# Altium schematic sheet LClock.SchDoc(LClock)
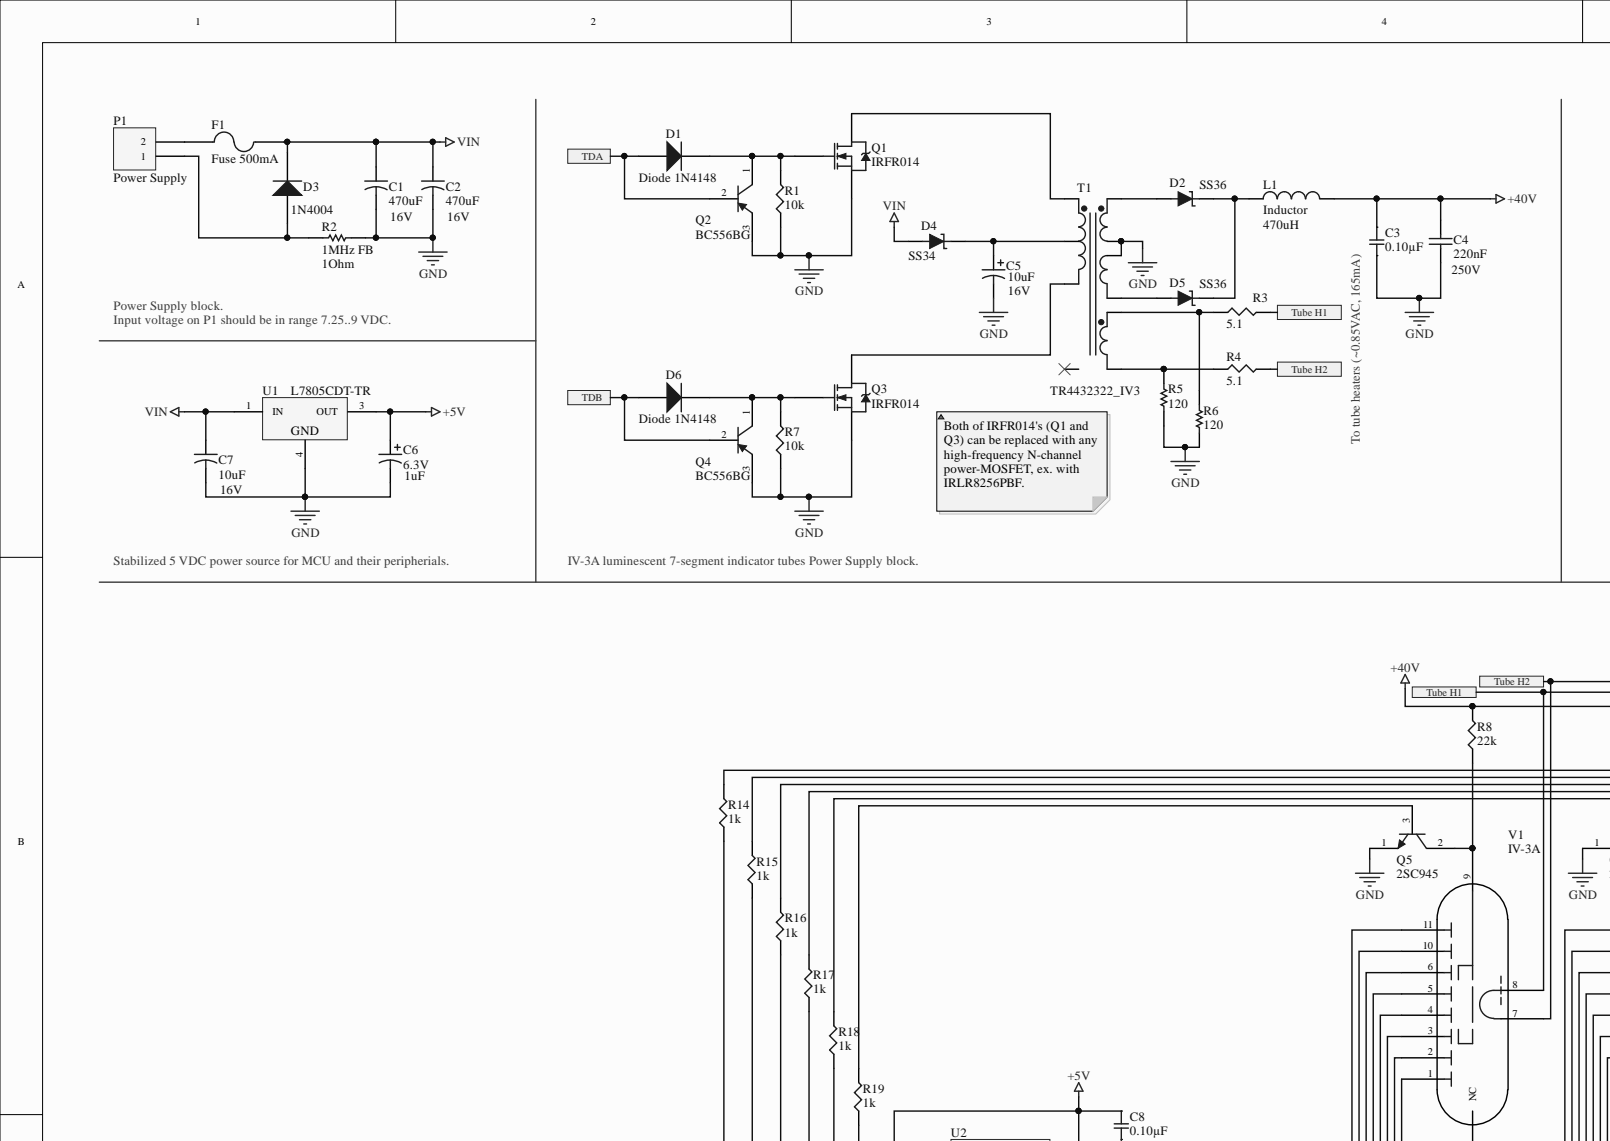
[diagram p0: LClock.SchDoc, top left]
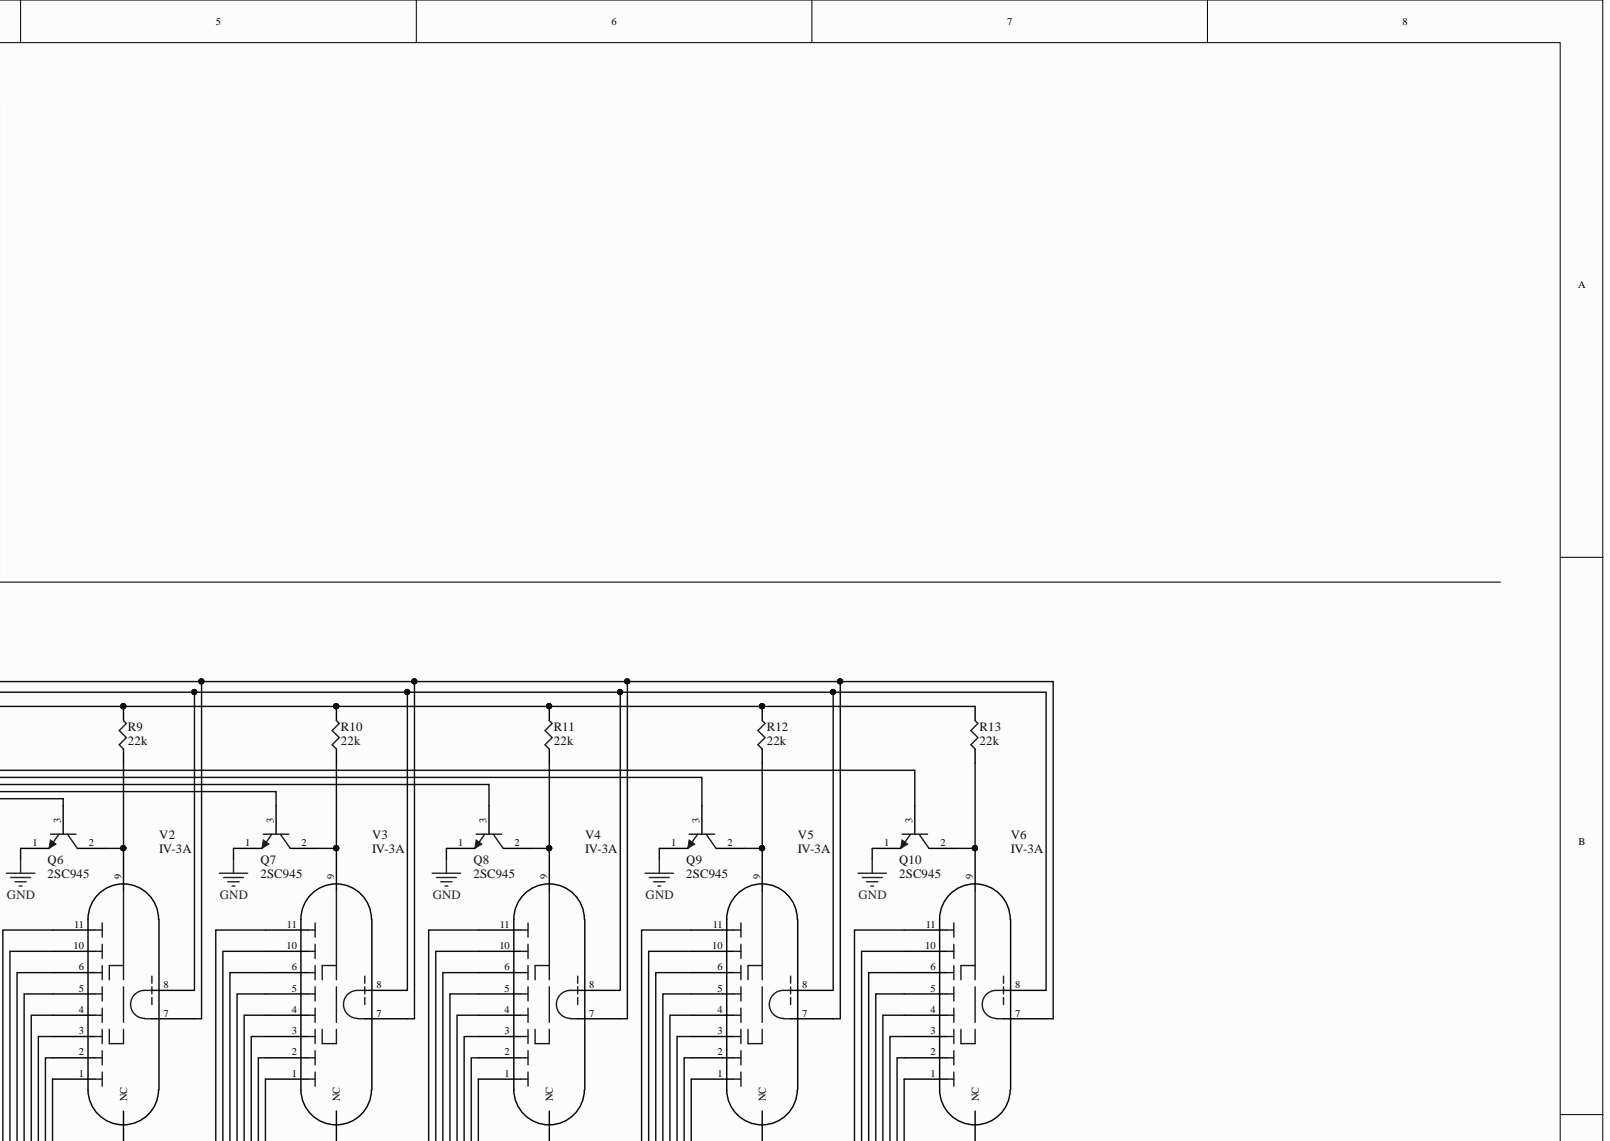
[diagram p1: LClock.SchDoc, top right]
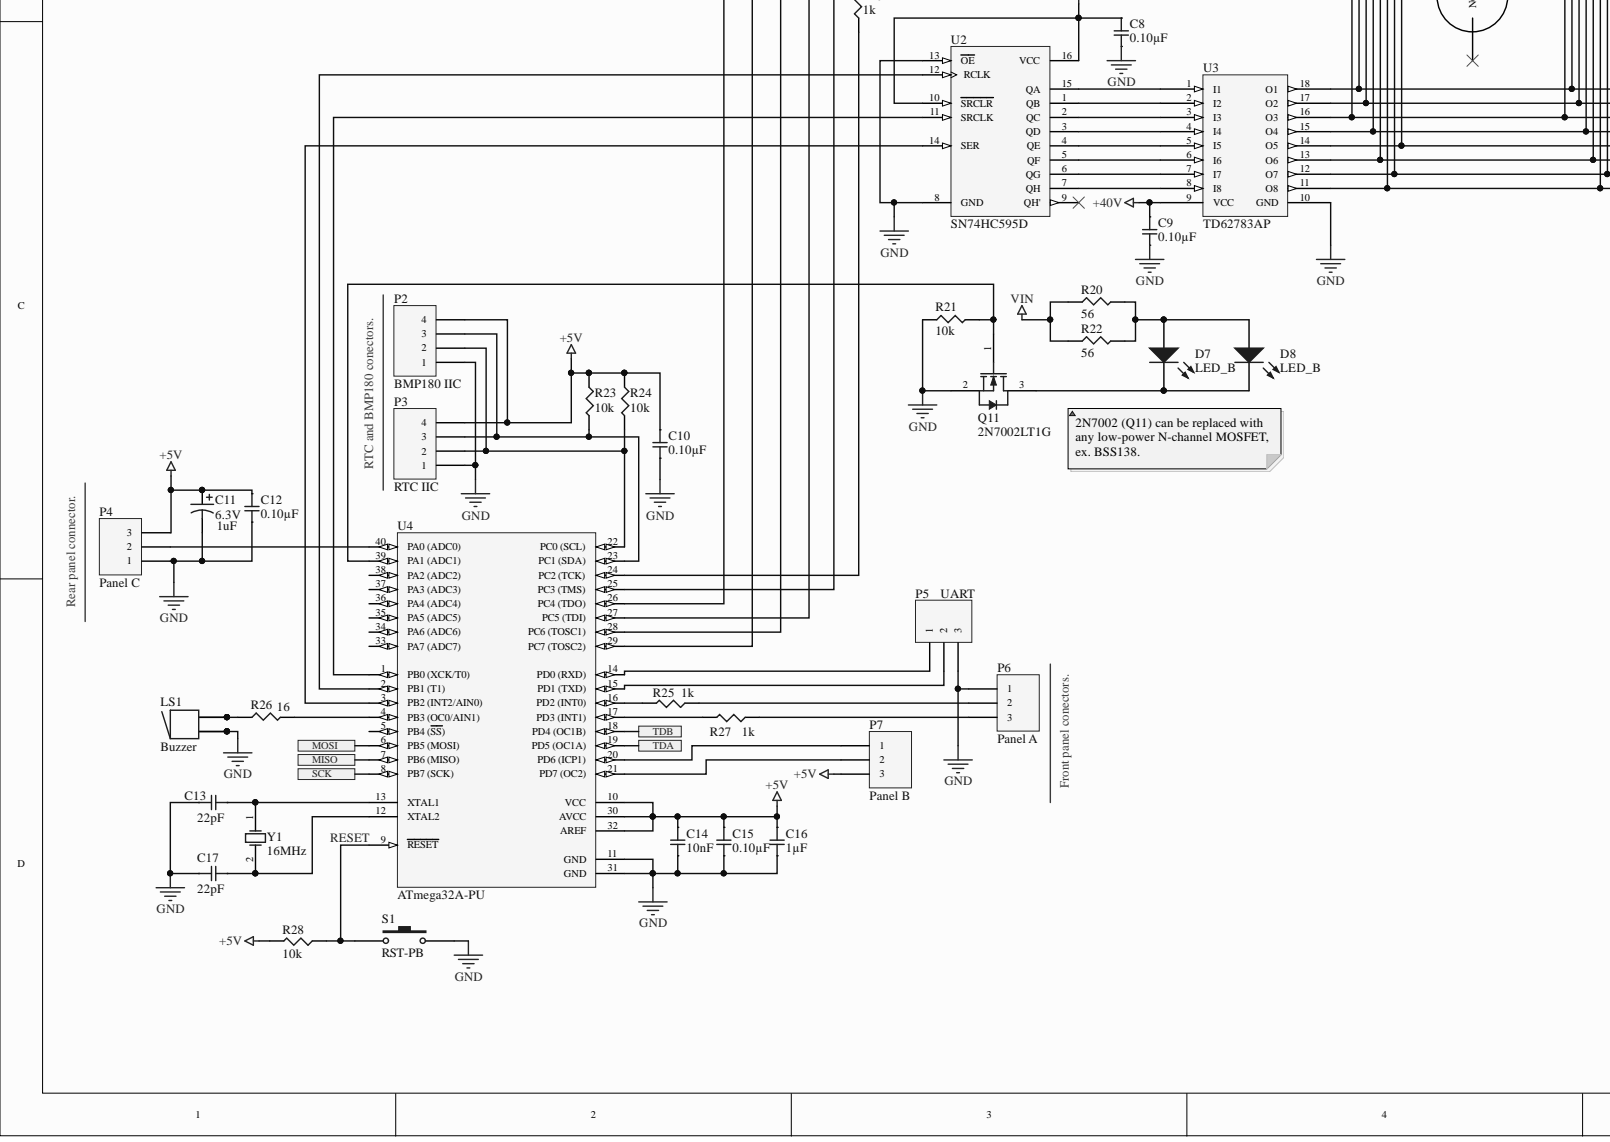
[diagram p2: LClock.SchDoc, bottom left]
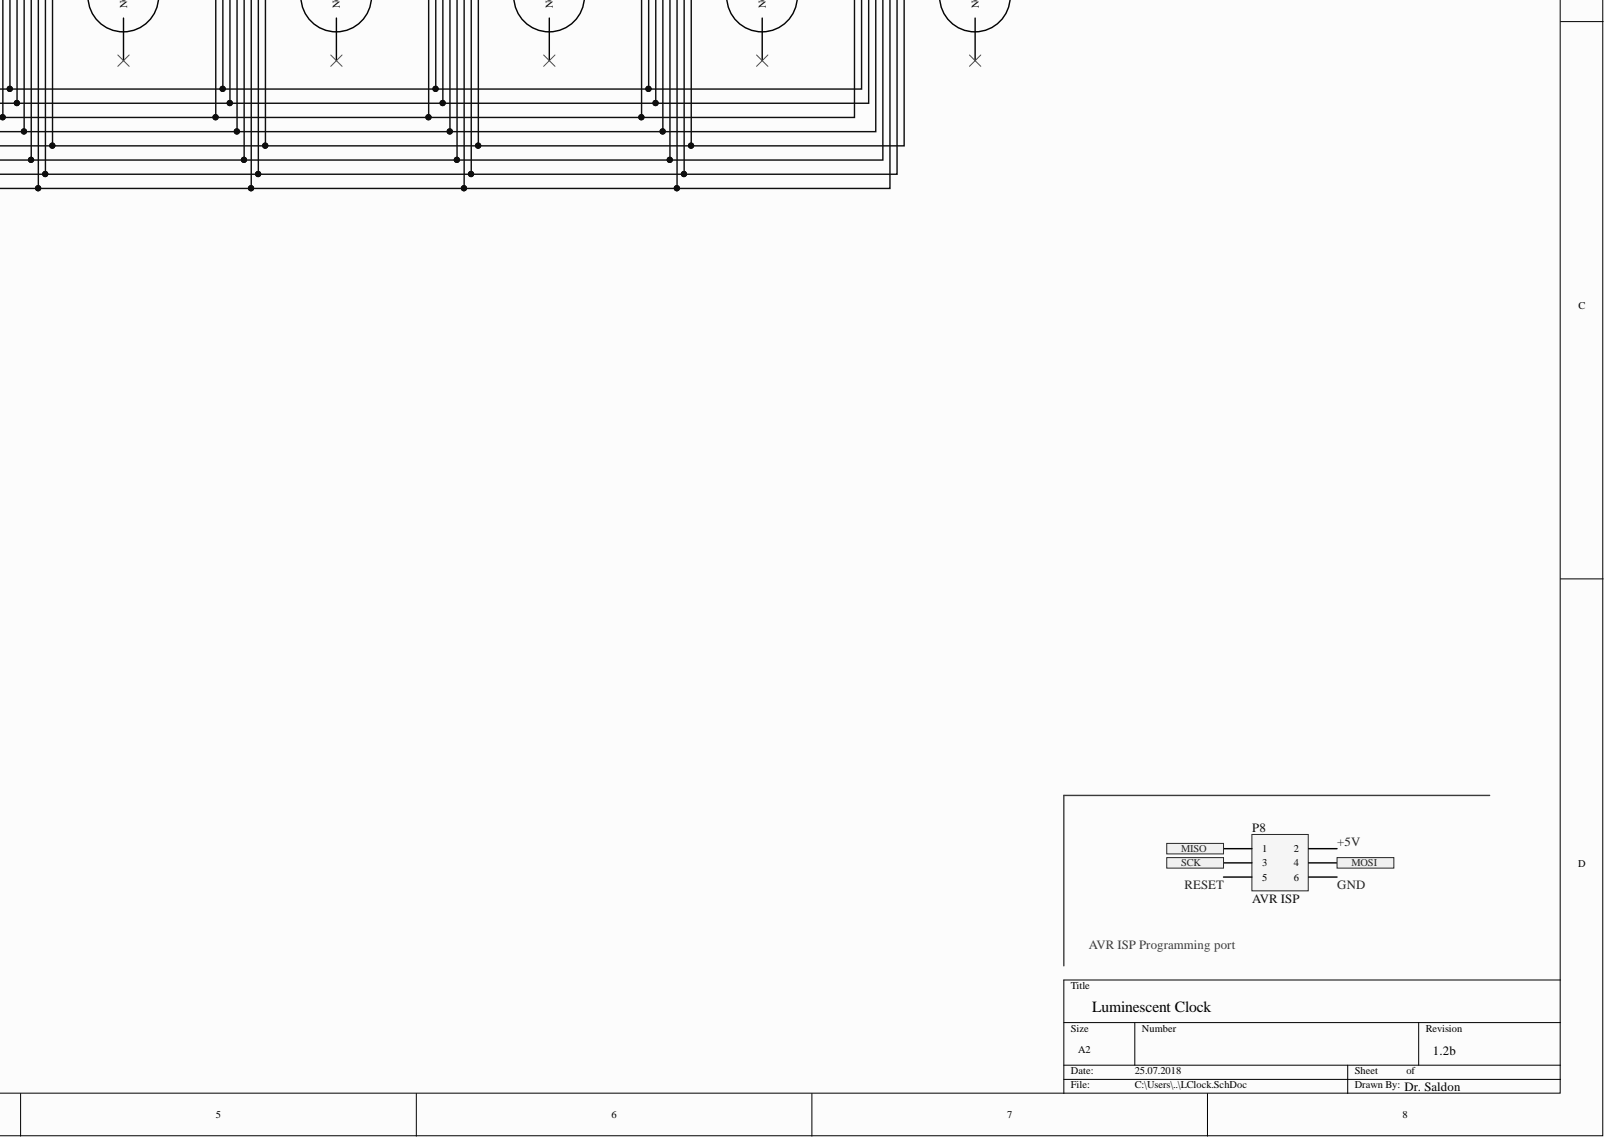
[diagram p3: LClock.SchDoc, bottom right]

## Parts
C1  16V  value 470uF  [CAPR5-4X5]
C2  16V  value 470uF  [CAPR5-4X5]
C3  0.10µF  [SMD-1206C]
C4  250V  value 220nF  [RAD-0.3]
C5  16V  value 10uF  [C']
C6  6.3V  value 1uF  [A]
C7  16V  value 10uF  [CAPR5-4X5]
C8  0.10µF  [SMD-1206C]
C9  0.10µF  [SMD-1206C]
C10  0.10µF  [SMD-1206C]
C11  6.3V  value 1uF  [A]
C12  0.10µF  [SMD-1206C]
C13  22pF  [SMD-0805C]
C14  10nF  [SMD-0805C]
C15  0.10µF  [SMD-1206C]
C16  1µF  [SMD-1206C]
C17  22pF  [SMD-0805C]
D1  Diode 1N4148  [DO-35]
D2  SS36  [DO-214AB (SMC)]
D3  1N4004  [DO-41]
D4  SS34  [DO-214AB (SMC)]
D5  SS36  [DO-214AB (SMC)]
D6  Diode 1N4148  [DO-35]
D7  LED_B  [LED-1]
D8  LED_B  [LED-1]
F1  Fuse 500mA  [PIN-W2/E2.8]
L1  Inductor  value 470uH  [INDC3216]
LS1  Buzzer  [ABSM-1574]
P1  Power Supply  [HDR1X2]
P2  BMP180 IIC  [HDR1X4]
P3  RTC IIC  [HDR1X4]
P4  Panel C  [HDR1X3]
P5  UART  [HDR1X3]
P6  Panel A  [HDR1X3]
P7  Panel B  [HDR1X3]
P8  AVR ISP  [HDR2X3]
Q1  IRFR014  [TO-252AA_N]
Q2  BC556BG  [TO-262-AA]
Q3  IRFR014  [TO-252AA_N]
Q4  BC556BG  [TO-262-AA]
Q5  2SC945  [TO-262-AA]
Q6  2SC945  [TO-262-AA]
Q7  2SC945  [TO-262-AA]
Q8  2SC945  [TO-262-AA]
Q9  2SC945  [TO-262-AA]
Q10  2SC945  [TO-262-AA]
Q11  2N7002LT1G  [ONSC-SOT-23-3-318-08_V]
R1  10k  [SMD-0805-RES]
R2  1MHz FB  value 1Ohm  [AXIAL-0.3]
R3  5.1  [SMD-0805-RES]
R4  5.1  [SMD-0805-RES]
R5  120  [R6.3x2.5]
R6  120  [R6.3x2.5]
R7  10k  [SMD-0805-RES]
R8  22k  [SMD-0805-RES]
R9  22k  [SMD-0805-RES]
R10  22k  [SMD-0805-RES]
R11  22k  [SMD-0805-RES]
R12  22k  [SMD-0805-RES]
R13  22k  [SMD-0805-RES]
R14  1k  [SMD-0805-RES]
R15  1k  [SMD-0805-RES]
R16  1k  [SMD-0805-RES]
R17  1k  [SMD-0805-RES]
R18  1k  [SMD-0805-RES]
R19  1k  [SMD-0805-RES]
R20  56  [SMD-0805-RES]
R21  10k  [SMD-0805-RES]
R22  56  [SMD-0805-RES]
R23  10k  [SMD-0805-RES]
R24  10k  [SMD-0805-RES]
R25  1k  [SMD-0805-RES]
R26  16  [SMD-0805-RES]
R27  1k  [SMD-0805-RES]
R28  10k  [SMD-0805-RES]
S1  RST-PB  [SPST-2]
T1  TR4432322_IV3  [TRE25-13-7]
U1  L7805CDT-TR  [TO-252_N]
U2  SN74HC595D  [D016_N]
U3  TD62783AP  [DIP-18]
U4  ATmega32A-PU  [40P6]
V1  IV-3A  [IV-3A_tube]
V2  IV-3A  [IV-3A_tube]
V3  IV-3A  [IV-3A_tube]
V4  IV-3A  [IV-3A_tube]
V5  IV-3A  [IV-3A_tube]
V6  IV-3A  [IV-3A_tube]
Y1  16MHz  [HC-49U]

## Ports
MISO, MOSI, SCK, TDA, TDB, Tube H1, Tube H2

## Nets
+40V: C3.1 C4.2 C9.1 L1.2 R8.2 R9.2 R10.2 R11.2 R12.2 R13.2 U3.9(VCC)
VIN: C1.1 C2.1 C7.1 D3.2 D4.1 F1.2 R20.1 R22.1 U1.1
+5V: C6.1 C8.1 C10.1 C11.1 C12.1 C14.1 C15.1 C16.1 P2.4 P3.4 P4.3 P7.3 P8.2 R23.1 R24.1 R28.2 U1.3 U2.10(SRCLR) U2.16(VCC) U4.10(VCC) U4.30(AVCC) U4.32(AREF)
GND: C1.2 C2.2 C3.2 C4.1 C5.2 C6.2 C7.2 C8.2 C9.2 C10.2 C11.2 C12.2 C13.1 C14.2 C15.2 C16.2 C17.1 LS1.1 LS1.1A P2.1 P3.1 P4.1 P5.3 P6.1 P8.6 Q1.3 Q2.3 Q3.3 Q4.3 Q5.1 Q6.1 Q7.1 Q8.1 Q9.1 Q10.1 Q11.2 R1.1 R2.1 R5.1 R6.1 R7.1 R21.1 S1.2 T1.7 T1.8 U1.4 U2.8(GND) U2.13(OE) U3.10(GND) U4.11(GND) U4.31(GND)
MISO: P8.1 U4.7(PB6_(MISO)) ; port MISO
MOSI: P8.4 U4.6(PB5_(MOSI)) ; port MOSI
RESET: P8.5 R28.1 S1.1 U4.9(RESET)
SCK: P8.3 U4.8(PB7_(SCK)) ; port SCK
TDA: D1.1 Q2.2 U4.19(PD5_(OC1A)) ; port TDA
TDB: D6.1 Q4.2 U4.18(PD4_(OC1B)) ; port TDB
Tube H1: R3.2 V1.8 V2.8 V3.8 V4.8 V5.8 V6.8 ; port Tube H1
Tube H2: R4.2 V1.7 V2.7 V3.7 V4.7 V5.7 V6.7 ; port Tube H2
NetC5_1: C5.1 D4.2 T1.3
NetC13_2: C13.2 U4.13(XTAL1) Y1.1
NetC17_2: C17.2 U4.12(XTAL2) Y1.2
NetD1_2: D1.2 Q1.1 Q2.1 R1.2
NetD2_1: D2.1 T1.6
NetD2_2: D2.2 D5.2 L1.1
NetD3_1: D3.1 P1.1 R2.2
NetD5_1: D5.1 T1.9
NetD6_2: D6.2 Q3.1 Q4.1 R7.2
NetD7_1: D7.1 D8.1 R20.2 R22.2
NetD7_2: D7.2 D8.2 Q11.3
NetF1_1: F1.1 P1.2
NetLS1_2: LS1.2 LS1.2A R26.1
NetP2_2: P2.2 P3.2 R24.2 U4.22(PC0_(SCL))
NetP2_3: P2.3 P3.3 R23.2 U4.23(PC1_(SDA))
NetP4_2: P4.2 U4.40(PA0_(ADC0))
NetP5_1: P5.1 U4.14(PD0_(RXD))
NetP5_2: P5.2 U4.15(PD1_(TXD))
NetP6_2: P6.2 R25.1
NetP6_3: P6.3 R27.1
NetP7_1: P7.1 U4.20(PD6_(ICP1))
NetP7_2: P7.2 U4.21(PD7_(OC2))
NetQ1_4: Q1.4 T1.1
NetQ3_4: Q3.4 T1.5
NetQ5_2: Q5.2 R8.1 V1.9
NetQ5_3: Q5.3 R19.2
NetQ6_2: Q6.2 R9.1 V2.9
NetQ6_3: Q6.3 R18.2
NetQ7_2: Q7.2 R10.1 V3.9
NetQ7_3: Q7.3 R17.2
NetQ8_2: Q8.2 R11.1 V4.9
NetQ8_3: Q8.3 R16.2
NetQ9_2: Q9.2 R12.1 V5.9
NetQ9_3: Q9.3 R15.2
NetQ10_2: Q10.2 R13.1 V6.9
NetQ10_3: Q10.3 R14.2
NetQ11_1: Q11.1 R21.2 U4.39(PA1_(ADC1))
NetR3_1: R3.1 R6.2 T1.2
NetR4_1: R4.1 R5.2 T1.4
NetR14_1: R14.1 U4.26(PC4_(TDO))
NetR15_1: R15.1 U4.29(PC7_(TOSC2))
NetR16_1: R16.1 U4.28(PC6_(TOSC1))
NetR17_1: R17.1 U4.27(PC5_(TDI))
NetR18_1: R18.1 U4.25(PC3_(TMS))
NetR19_1: R19.1 U4.24(PC2_(TCK))
NetR25_2: R25.2 U4.16(PD2_(INT0))
NetR26_2: R26.2 U4.4(PB3_(OC0/AIN1))
NetR27_2: R27.2 U4.17(PD3_(INT1))
NetU2_1: U2.1(QB) U3.2(I2)
NetU2_2: U2.2(QC) U3.3(I3)
NetU2_3: U2.3(QD) U3.4(I4)
NetU2_4: U2.4(QE) U3.5(I5)
NetU2_5: U2.5(QF) U3.6(I6)
NetU2_6: U2.6(QG) U3.7(I7)
NetU2_7: U2.7(QH) U3.8(I8)
NetU2_11: U2.11(SRCLK) U4.1(PB0_(XCK/T0))
NetU2_12: U2.12(RCLK) U4.2(PB1_(T1))
NetU2_14: U2.14(SER) U4.3(PB2_(INT2/AIN0))
NetU2_15: U2.15(QA) U3.1(I1)
NetU3_11: U3.11(O8) V1.3 V2.3 V3.3 V4.3 V5.3 V6.3
NetU3_12: U3.12(O7) V1.2 V2.2 V3.2 V4.2 V5.2 V6.2
NetU3_13: U3.13(O6) V1.4 V2.4 V3.4 V4.4 V5.4 V6.4
NetU3_14: U3.14(O5) V1.1 V2.1 V3.1 V4.1 V5.1 V6.1
NetU3_15: U3.15(O4) V1.5 V2.5 V3.5 V4.5 V5.5 V6.5
NetU3_16: U3.16(O3) V1.11 V2.11 V3.11 V4.11 V5.11 V6.11
NetU3_17: U3.17(O2) V1.6 V2.6 V3.6 V4.6 V5.6 V6.6
NetU3_18: U3.18(O1) V1.10 V2.10 V3.10 V4.10 V5.10 V6.10

Not connected: T1 10; U2 9(QH'); U4 5(PB4_(SS)) 33(PA7_(ADC7)) 34(PA6_(ADC6)) 35(PA5_(ADC5)) 36(PA4_(ADC4)) 37(PA3_(ADC3)) 38(PA2_(ADC2)); V1 12(NC); V2 12(NC); V3 12(NC); V4 12(NC); V5 12(NC); V6 12(NC)

## Library data of the parts
C1 C2 C7 = Cap2: Capacitor; Simulation: CAP; Signal Integrity: Cap; Published: 23-Sep-2002; PackageReference: CAPR5-4X5; PackageDescription: Capacitor; 2 Leads; Library Name: Miscellaneous Devices.IntLib; Component Kind: Standard; Publisher: Altium Limited
C3 = GRJ31MR71H104KE01L: CAP CER 0.1UF 50V X7R 1206; Manufacturer: Murata Electronics North America; Unit Price (USD): 0.25; Tolerance: ±10%; Minimum Quantity: 1; Factory Stock: 0; Voltage: 50V; Value: 0.10µF; Thickness (Max): 0.053" (1.35mm); Temperature Coefficient: X7R; Size / Dimension: 0.126" L x 0.063" W (3.20mm x 1.60mm); Series: GRJ; Ratings: -; Quantity Available: 5980; Part Status: Active; Part Number: GRJ31MR71H104KE01L; Packaging: Cut Tape (CT); Package / Case: 1206 (3216 Metric); Operating Temperature: -55°C ~ 125°C; Mounting Type: Surface Mount, MLCC; Library Name: capacitor_murata_1206.IntLib; Lead Style: -; Lead Spacing: -; Image: //media.digikey.com/Renders/Murata%20Renders/GR331BD72E473KW01L.jpg; Height - Seated (Max): -; Features: Soft Termination; Digi-Key Part Number: 490-10950-1-ND; Datasheets: http://www.murata.com/~/media/webrenewal/support/library/catalog/products/capacitor/mlcc/c02e.pdf; Component Kind: Standard; Applications: Boardflex Sensitive; @ qty: 0
C4 = Cap: Capacitor; Simulation: CAP; Signal Integrity: Cap; Published: 8-Jun-2000; PackageReference: RAD-0.3; PackageDescription: Radial Cap, Thru-Hole; 2 Leads; 0.3 in Pin Spacing; Library Name: Miscellaneous Devices.IntLib; LatestRevisionNote: Re-released for DXP Platform.; LatestRevisionDate: 17-Jul-2002; Component Kind: Standard; Publisher: Altium Limited
C5 = 94SL106X0016CBP: Solid Aluminum Capacitor with Organic Semiconductor Electrolyte: 10uF, 16V, Bulk Pack; PCB_Layout: Complies with Manufacturer's Recommendation.; Note: Part Numbers shown are for ± 20% capacitance tolerance (X0).; DrillSize: Nominal, Rounded to the nearest 0.1mm; Simulation: CAP; Signal Integrity: 94SL106X0016CBP; Published: 19-Mar-2004; PackageReference: C'; Library Name: Vishay Electrolytic Radial-Lead Capacitor.IntLib; DatasheetDocument: 23-May-2001; ComponentLink2URL: http://www.vishay.com/docs/90005/94sl.pdf; ComponentLink2Description: Datasheet; ComponentLink1URL: http://www.vishay.com/; ComponentLink1Description: Manufacturer Link; Component Kind: Standard; Publisher: Altium Limited
C6 C11 = 94SC105X0016ABP: Solid Aluminum Capacitor with Organic Semiconductor Electrolyte: 1uF, 16V, Bulk Pack; PCB_Layout: Complies with Manufacturer's Recommendation.; Note: Part Numbers shown are for ± 20% capacitance tolerance (X0).; DrillSize: Nominal, Rounded to the nearest 0.1mm; Simulation: CAP; Signal Integrity: 94SC105X0016ABP; Published: 19-Mar-2004; PackageReference: A; Library Name: Vishay Electrolytic Radial-Lead Capacitor.IntLib; DatasheetDocument: 23-May-2001; ComponentLink2URL: http://www.vishay.com/docs/90004/94sc.pdf; ComponentLink2Description: Datasheet; ComponentLink1URL: http://www.vishay.com/; ComponentLink1Description: Manufacturer Link; Component Kind: Standard; Publisher: Altium Limited
C8-C10 C12 C15 = GCJ31CR72E104KXJ3L: CAP CER 0.1UF 250V X7R 1206; Manufacturer: Murata Electronics North America; Unit Price (USD): 0.36; Tolerance: ±10%; Minimum Quantity: 1; Factory Stock: 0; Voltage: 250V; Value: 0.10µF; Thickness (Max): 0.071" (1.80mm); Temperature Coefficient: X7R; Size / Dimension: 0.126" L x 0.063" W (3.20mm x 1.60mm); Series: GCJ; Ratings: AEC-Q200; Quantity Available: 3645; Part Status: Active; Part Number: GCJ31CR72E104KXJ3L; Packaging: Cut Tape (CT); Package / Case: 1206 (3216 Metric); Operating Temperature: -55°C ~ 125°C; Mounting Type: Surface Mount, MLCC; Library Name: capacitor_murata_1206.IntLib; Lead Style: -; Lead Spacing: -; Image: //media.digikey.com/Renders/Murata%20Renders/GR331BD72E473KW01L.jpg; Height - Seated (Max): -; Features: Soft Termination; Digi-Key Part Number: 490-12647-1-ND; Datasheets: http://www.murata.com/~/media/webrenewal/support/library/catalog/products/capacitor/mlcc/c03e.ashx; Component Kind: Standard; Applications: Automotive, Boardflex Sensitive; @ qty: 0
C13 C17 = GQM2195C1H220GB01D: CAP CER 22PF 50V NP0 0805; Manufacturer: Murata Electronics North America; Unit Price (USD): 1.26; Tolerance: ±2%; Temperature Coefficient: C0G, NP0; Minimum Quantity: 1; Factory Stock: 0; Voltage: 50V; Value: 22pF; Thickness (Max): 0.037" (0.95mm); Size / Dimension: 0.079" L x 0.049" W (2.00mm x 1.25mm); Series: GQM; Ratings: -; Quantity Available: 2356; Part Status: Active; Part Number: GQM2195C1H220GB01D; Packaging: Cut Tape (CT); Package / Case: 0805 (2012 Metric); Operating Temperature: -55°C ~ 125°C; Mounting Type: Surface Mount, MLCC; Library Name: capacitor_murata_0805.IntLib; Lead Style: -; Lead Spacing: -; Image: //media.digikey.com/Renders/Murata%20Renders/0805(GxM9).jpg; Height - Seated (Max): -; Features: High Q, Low Loss; Digi-Key Part Number: 490-6097-1-ND; Datasheets: http://www.murata.com/~/media/webrenewal/support/library/catalog/products/capacitor/mlcc/c02e.pdf; Component Kind: Standard; Applications: RF, Microwave, High Frequency; @ qty: 0
C14 = GCM216R71H103KA37D: CAP CER 10000PF 50V X7R 0805; Manufacturer: Murata Electronics North America; Unit Price (USD): 0.12; Tolerance: ±10%; Minimum Quantity: 1; Factory Stock: 0; Voltage: 50V; Value: 10000pF; Thickness (Max): 0.028" (0.70mm); Temperature Coefficient: X7R; Size / Dimension: 0.079" L x 0.049" W (2.00mm x 1.25mm); Series: GCM; Ratings: AEC-Q200; Quantity Available: 1013; Part Status: Active; Part Number: GCM216R71H103KA37D; Packaging: Cut Tape (CT); Package / Case: 0805 (2012 Metric); Operating Temperature: -55°C ~ 125°C; Mounting Type: Surface Mount, MLCC; Library Name: capacitor_murata_0805.IntLib; Lead Style: -; Lead Spacing: -; Image: //media.digikey.com/Renders/Murata%20Renders/0805%28GxM6%29.jpg; Height - Seated (Max): -; Features: -; Digi-Key Part Number: 490-6058-1-ND; Datasheets: http://www.murata.com/~/media/webrenewal/support/library/catalog/products/capacitor/mlcc/c03e.ashx; Component Kind: Standard; Applications: Automotive; @ qty: 0
C16 = GCJ31MR71H105KA12L: CAP CER 1UF 50V X7R 1206; Manufacturer: Murata Electronics North America; Unit Price (USD): 0.32; Tolerance: ±10%; Minimum Quantity: 1; Factory Stock: 0; Voltage: 50V; Value: 1µF; Thickness (Max): 0.053" (1.35mm); Temperature Coefficient: X7R; Size / Dimension: 0.126" L x 0.063" W (3.20mm x 1.60mm); Series: GCJ; Ratings: AEC-Q200; Quantity Available: 38710; Part Status: Active; Part Number: GCJ31MR71H105KA12L; Packaging: Cut Tape (CT); Package / Case: 1206 (3216 Metric); Operating Temperature: -55°C ~ 125°C; Mounting Type: Surface Mount, MLCC; Library Name: capacitor_murata_1206.IntLib; Lead Style: -; Lead Spacing: -; Image: //media.digikey.com/Renders/Murata%20Renders/GR331BD72E473KW01L.jpg; Height - Seated (Max): -; Features: Soft Termination; Digi-Key Part Number: 490-5810-1-ND; Datasheets: http://www.murata.com/~/media/webrenewal/support/library/catalog/products/capacitor/mlcc/c03e.ashx; Component Kind: Standard; Applications: Automotive, Boardflex Sensitive; @ qty: 0
D1 D6 = Diode 1N4148: High Conductance Fast Diode; Simulation: 1N4148; Signal Integrity: 1N4148; Published: 8-Jun-2000; PackageReference: DO-35; PackageDescription: Diode, Axial; Body 3.8 x 1.9 mm (LxDia. max), Lead Dia. 0.53 mm (max); Library Name: Miscellaneous Devices.IntLib; LatestRevisionNote: Re-released for DXP Platform.; LatestRevisionDate: 17-Jul-2002; DatasheetVersion: 1997; ComponentLink2URL: http://www.fairchildsemi.com/ds/1N%2F1N4148.pdf; ComponentLink2Description: Datasheet; ComponentLink1URL: http://www.fairchildsemi.com/; ComponentLink1Description: Manufacturer Link; Component Kind: Standard; Code_JEDEC: DO-204-AH; Publisher: Altium Limited
D2 D5 = SS54: SMD SMC 60V 3A; Signal Integrity: D; Price: 0.23; Library Name: Diodes-Power.IntLib; Component Kind: Standard
D3 = Diode 1N4004: 1 Amp General Purpose Rectifier; Simulation: 1N4004; Signal Integrity: 1N4004; Published: 8-Jun-2000; PackageVersion: Aug-1999; PackageReference: DO-41; PackageDescription: Diode, Axial; Body 5.2 x 2.7 mm (LxDia. max), Lead Dia. 0.86 mm (max); Library Name: Miscellaneous Devices.IntLib; LatestRevisionNote: Re-released for DXP Platform.; LatestRevisionDate: 17-Jul-2002; DatasheetVersion: 1998; ComponentLink2URL: http://www.fairchildsemi.com/ds/1N%2F1N4001.pdf; ComponentLink2Description: Datasheet; ComponentLink1URL: http://www.fairchildsemi.com/; ComponentLink1Description: Manufacturer Link; Component Kind: Standard; Code_JEDEC: DO-204-AL; Publisher: Altium Limited
D4 = SS34: SMD SMC  40V 3A; Signal Integrity: D; Price: 0.14; Library Name: Diodes-Power.IntLib; Component Kind: Standard
D7 D8 = LED1: Typical BLUE GaAs LED; Simulation: LED1; Published: 8-Jun-2000; PackageReference: LED-1; PackageDescription: LED; 2 Leads; Library Name: Miscellaneous Devices.IntLib; LatestRevisionNote: Mech Layer 1 removed.; LatestRevisionDate: 08-Jul-2005; Component Kind: Standard; Publisher: Altium Limited
F1 = Fuse 2: Fuse; Simulation: FUSE; Published: 8-Jun-2000; PackageReference: PIN-W2/E2.8; PackageDescription: Thru-Hole; 2 Leads; Library Name: Miscellaneous Devices.IntLib; LatestRevisionNote: Re-released for DXP Platform.; LatestRevisionDate: 17-Jul-2002; Component Kind: Standard; Publisher: Altium Limited
L1 = Inductor: Code_IEC: 1005; Simulation: INDUCTOR; Signal Integrity: Inductor; Published: 8-Jun-2000; PackageReference: 0402-A; PackageDescription: Chip Inductor; Library Name: Miscellaneous Devices.IntLib; LatestRevisionNote: IPC-7351 Footprint Added.; LatestRevisionDate: 29-May-2009; Description: Inductor; Component Kind: Standard; Code_JEITA: 0402; Publisher: Altium Limited
LS1 = Buzzer: Magnetic Transducer Buzzer; Published: 24-Mar-1999; PackageReference: ABSM-1574; PackageDescription: Magnetic Transducer Buzzer; Library Name: Miscellaneous Devices.IntLib; LatestRevisionNote: Schematic revised, PCB Footprint replaced.; LatestRevisionDate: 17-Dec-2003; DatasheetVersion: 17-Dec-2003; ComponentLink1URL: http://www.megastar.com/linecard/buzzmode/index.php; ComponentLink1Description: Manufacturer Link; Component Kind: Standard; Publisher: Altium Limited
P1 = Header 2: Header, 2-Pin; Signal Integrity: Connector; Library Name: Miscellaneous Connectors.IntLib; LatestRevisionNote: Re-released for DXP Platform.; LatestRevisionDate: 17-Jul-2002; Component Kind: Standard; Publisher: Altium Limited
P2 P3 = Header 4: Header, 4-Pin; Signal Integrity: Connector; Library Name: Miscellaneous Connectors.IntLib; LatestRevisionNote: Re-released for DXP Platform.; LatestRevisionDate: 17-Jul-2002; Component Kind: Standard; Publisher: Altium Limited
P4-P7 = Header 3: Header, 3-Pin; Signal Integrity: Connector; Library Name: Miscellaneous Connectors.IntLib; LatestRevisionNote: Re-released for DXP Platform.; LatestRevisionDate: 17-Jul-2002; Component Kind: Standard; Publisher: Altium Limited
P8 = Header 3X2: Header, 3-Pin, Dual row; Signal Integrity: Connector; Library Name: Miscellaneous Connectors.IntLib; LatestRevisionNote: Re-released for DXP Platform.; LatestRevisionDate: 17-Jul-2002; Component Kind: Standard; Publisher: Altium Limited
Q1 Q3 = IRFR014: HEXFET N-Channel Power MOSFET; Note: Pin 2 is trimmed and is electrically connected to Drain.; Simulation: IRFR014; Published: 8-Jun-2000; PackageVersion: Feb-2003; PackageReference: TO-252AA; PackageDescription: 3-Pin HEXFET Package 2.28 mm Pitch, 9.9 mm Lead Span; Library Name: IR Discrete MOSFET - Power.IntLib; LatestRevisionNote: IPC-7351 Footprint Added.; LatestRevisionDate: 20-Nov-2009; DatasheetVersion: PD-9.7014; ComponentLink2URL: http://www.irf.com/product-info/datasheets/data/irfr014.pdf; ComponentLink2Description: Datasheet; ComponentLink1URL: http://www.irf.com/; ComponentLink1Description: Manufacturer Link; Component Kind: Standard; Code_JEDEC: TO-252-AA; Publisher: Altium Limited
Q2 Q4 = BC556BG: Amplifier Transistor, PNP Silicon, 3-Pin TO-92, Pb-Free, Bulk Box; Manufacturer: On Semiconductor; IC Continuous (A): -0.1; Supplier Part Number 3: 863-BC556BG; Supplier Part Number 2: 26K3439; Supplier Part Number 1: BC556BGOS-ND; Supplier 3: Mouser; Supplier 2: Newark; Supplier 1: Digi-Key; RoHS: TRUE; Polarity: PNP; PartNumber: BC556BG; Packing: Bulk Box; PackageVersion: Rev. AM; PackageReference: TO-92-3-29-11_AM_SL; PackageDescription: 3-Pin Transistor Outline, Body 4.825 x 3.685 mm, Pitch 1.27 mm; Mounting Technology: Through Hole; Library Name: ON Semi General Purpose Transistors.IntLib; DatasheetVersion: Rev. 3; ComponentLink3URL: http://www.onsemi.cn/pub_link/Collateral/2N6426-D.PDF; ComponentLink3Description: Package Specification; ComponentLink2URL: http://www.onsemi.com/pub_link/Collateral/BC556B-D.PDF; ComponentLink2Description: Datasheet; ComponentLink1URL: http://www.onsemi.com/; ComponentLink1Description: Manufacturer URL; Component Kind: Standard
Q5-Q10 = 2SD1012G-SPA: Bipolar Transistor, 50 V, 0.1 A, NPN Single, 3-Pin TO-92; Manufacturer: On Semiconductor; V(BR)CEO Min (V): 15; Packing: Bulk Bag; IC Continuous (A): 0.7; hFE Min: 280; hFE Max: 560; Supplier Part Number 2: 863-2SD1012G-SPA; Supplier Part Number 1: 2SD1012G-SPA-ND; Supplier 2: Mouser; Supplier 1: Digi-Key; RoHS: TRUE; Polarity: NPN; PartNumber: 2SD1012G-SPA; PackageVersion: Rev. O, 02/2012; PackageReference: TO-92-3-135AC; PackageDescription: 3-Pin Transistor Outline, Body 4 x 2.2 mm, Pitch 1.3 mm; Mounting Technology: Through Hole; ComponentLink3URL: http://www.onsemi.com/pub_link/Collateral/135AC.PDF; ComponentLink3Description: Package Specification; ComponentLink2URL: http://www.onsemi.com/pub_link/Collateral/EN0676-D.PDF; ComponentLink2Description: Datasheet; ComponentLink1URL: http://www.onsemi.com/; ComponentLink1Description: Manufacturer URL; Component Kind: Standard; Library Name: ON Semi Low VCE(Sat) Transistors.IntLib
Q11 = 2N7002LT1G: Small Signal MOSFET, 60 V, 115 mA, N-Channel, 3-Pin SOT-23, Pb-Free, Tape and Reel; Manufacturer: On Semiconductor; VGS Max (V): 20; VGS(th) Max (V): 2.5; V(BR)DSS Min (V): 60; ID Max (A): 0.115; Configuration: Single; Channel Polarity: N Channel; Supplier Part Number 9: 863-2N7002LT1G; Supplier Part Number 8: 83H7920; Supplier Part Number 7: 67R1418; Supplier Part Number 6: 2363494; Supplier Part Number 5: 9558624; Supplier Part Number 4: 9558624RL; Supplier Part Number 3: 2N7002LT1GOSDKR-ND; Supplier Part Number 2: 2N7002LT1GOSCT-ND; Supplier Part Number 1: 2N7002LT1GOSTR-ND; Supplier 9: Mouser; Supplier 8: Newark; Supplier 7: Newark; Supplier 6: Farnell; Supplier 5: Farnell; Supplier 4: Farnell; Supplier 3: Digi-Key; Supplier 2: Digi-Key; Supplier 1: Digi-Key; RoHS: Pb-Free; PartNumber: 2N7002LT1G; Packing: Tape and Reel; PackageVersion: Rev. AP, 11/2009; Package Type: SOT-23-3; PackageReference: SOT-23-3-318-08; PackageDescription: 3-Pin Small Outline Transistor, Body 2.92 x 1.3 mm, Pitch 0.95 mm; Mounting Technology: Surface Mount; Library Name: ON Semi MOSFETs.IntLib; DatasheetVersion: Rev. 7; ComponentLink3URL: http://www.onsemi.cn/pub_link/Collateral/318-08.PDF; ComponentLink3Description: Package Specification; ComponentLink2URL: http://www.onsemi.com/pub_link/Collateral/2N7002L-D.PDF; ComponentLink2Description: Datasheet; ComponentLink1URL: http://www.onsemi.com/; ComponentLink1Description: Manufacturer URL; Component Kind: Standard
R1 R7 R21 R23 R24 R28 = ERJ-6ENF1002V: RES SMD 10K OHM 1% 1/8W 0805; Manufacturer: Panasonic Electronic Components; Unit Price (USD): 0.00729; Temperature Coefficient: ±100ppm/°C; Power (Watts): 0.125W, 1/8W; Minimum Quantity: 1; Factory Stock: 0; @ qty: 1500; Value: 10k; Tolerance: ±1%; Supplier Device Package: 0805; Size / Dimension: 0.079" L x 0.049" W (2.00mm x 1.25mm); Series: ERJ; Quantity Available: 3985614; Part Status: Active; Part Number: ERJ-6ENF1002V; Packaging: Cut Tape (CT); Package / Case: 0805 (2012 Metric); Operating Temperature: -55°C ~ 155°C; Number of Terminations: 2; Library Name: Panasonic_ERJ_0805.IntLib; Image: //media.digikey.com/Renders/Panasonic%20Renders/ERJ-0805%20Pkg.jpg; Height - Seated (Max): 0.028" (0.70mm); Features: Automotive AEC-Q200; Failure Rate: -; Digi-Key Part Number: P10.0KCCT-ND; Datasheets: http://industrial.panasonic.com/www-cgi/jvcr13pz.cgi?E+PZ+3+AOA0002+ERJ6ENF1002V+7+WW; Composition: Thick Film; Component Kind: Standard
R2 = Res1: Resistor; Simulation: RESISTOR; Signal Integrity: Res; Published: 8-Jun-2000; PackageReference: AXIAL-0.3; PackageDescription: Axial Device, Thru-Hole; 2 Leads; 0.3 in Pin Spacing; Library Name: Miscellaneous Devices.IntLib; LatestRevisionNote: Re-released for DXP Platform.; LatestRevisionDate: 17-Jul-2002; Component Kind: Standard; Publisher: Altium Limited
R3 R4 = ERJ-6BQF5R1V: RES SMD 5.1 OHM 1% 1/3W 0805; Manufacturer: Panasonic Electronic Components; Unit Price (USD): 0.05834; Temperature Coefficient: ±200ppm/°C; Power (Watts): 0.333W, 1/3W; Minimum Quantity: 1; Factory Stock: 0; @ qty: 1500; Value: 5.1; Tolerance: ±1%; Supplier Device Package: 0805; Size / Dimension: 0.079" L x 0.049" W (2.00mm x 1.25mm); Series: ERJ; Quantity Available: 3194; Part Status: Active; Part Number: ERJ-6BQF5R1V; Packaging: Cut Tape (CT); Package / Case: 0805 (2012 Metric); Operating Temperature: -55°C ~ 125°C; Number of Terminations: 2; Library Name: Panasonic_ERJ_0805.IntLib; Image: //media.digikey.com/Renders/Panasonic%20Renders/ERJ-0805%20Pkg.jpg; Height - Seated (Max): 0.028" (0.70mm); Features: Automotive AEC-Q200, Current Sense; Failure Rate: -; Digi-Key Part Number: P17511CT-ND; Datasheets: https://industrial.panasonic.com/cdbs/www-data/pdf/RDA0000/AOA0000C239.pdf; Composition: Thick Film; Component Kind: Standard
R5 R6 = ERNSB: Precision Metal Film Resistor, High Stability, 100 Ohm to 150k Ohm Range, 0.1% to 1% Tolerance, 0.25 W; Simulation: RESISTOR; Signal Integrity: Res; Published: 17-Dec-2003; PackageReference: R6.3x2.5; Library Name: Panasonic Resistor.IntLib; ComponentLink3URL: http://www.panasonic.com/industrial/components/pdf/dc094.pdf; ComponentLink3Description: Discontinuation Notice; ComponentLink2URL: http://www.panasonic.com/industrial/components/pdf/AOA0000CE16.pdf; ComponentLink2Description: Datasheet; ComponentLink1URL: http://www.panasonic.com/industrial/components/resistive_products.htm; ComponentLink1Description: Manufacturer Link; Component Kind: Standard; Publisher: Altium Limited
R8-R13 = ERJ-6ENF2202V: RES SMD 22K OHM 1% 1/8W 0805; Manufacturer: Panasonic Electronic Components; Unit Price (USD): 0.00729; Temperature Coefficient: ±100ppm/°C; Power (Watts): 0.125W, 1/8W; Minimum Quantity: 1; Factory Stock: 0; @ qty: 1500; Value: 22k; Tolerance: ±1%; Supplier Device Package: 0805; Size / Dimension: 0.079" L x 0.049" W (2.00mm x 1.25mm); Series: ERJ; Quantity Available: 26536; Part Status: Active; Part Number: ERJ-6ENF2202V; Packaging: Cut Tape (CT); Package / Case: 0805 (2012 Metric); Operating Temperature: -55°C ~ 155°C; Number of Terminations: 2; Library Name: Panasonic_ERJ_0805.IntLib; Image: //media.digikey.com/Renders/Panasonic%20Renders/ERJ-0805%20Pkg.jpg; Height - Seated (Max): 0.028" (0.70mm); Features: Automotive AEC-Q200; Failure Rate: -; Digi-Key Part Number: P22.0KCCT-ND; Datasheets: http://industrial.panasonic.com/www-cgi/jvcr13pz.cgi?E+PZ+3+AOA0002+ERJ6ENF2202V+7+WW; Composition: Thick Film; Component Kind: Standard
R14-R19 R25 R27 = ERJ-6ENF1001V: RES SMD 1K OHM 1% 1/8W 0805; Manufacturer: Panasonic Electronic Components; Unit Price (USD): 0.00729; Temperature Coefficient: ±100ppm/°C; Power (Watts): 0.125W, 1/8W; Minimum Quantity: 1; Factory Stock: 0; @ qty: 1500; Value: 1k; Tolerance: ±1%; Supplier Device Package: 0805; Size / Dimension: 0.079" L x 0.049" W (2.00mm x 1.25mm); Series: ERJ; Quantity Available: 12895103; Part Status: Active; Part Number: ERJ-6ENF1001V; Packaging: Cut Tape (CT); Package / Case: 0805 (2012 Metric); Operating Temperature: -55°C ~ 155°C; Number of Terminations: 2; Library Name: Panasonic_ERJ_0805.IntLib; Image: //media.digikey.com/Renders/Panasonic%20Renders/ERJ-0805%20Pkg.jpg; Height - Seated (Max): 0.028" (0.70mm); Features: Automotive AEC-Q200; Failure Rate: -; Digi-Key Part Number: P1.00KCCT-ND; Datasheets: http://industrial.panasonic.com/www-cgi/jvcr13pz.cgi?E+PZ+3+AOA0002+ERJ6ENF1001V+7+WW; Composition: Thick Film; Component Kind: Standard
R20 R22 = ERJ-6ENF56R0V: RES SMD 56 OHM 1% 1/8W 0805; Manufacturer: Panasonic Electronic Components; Unit Price (USD): 0.00729; Temperature Coefficient: ±100ppm/°C; Power (Watts): 0.125W, 1/8W; Minimum Quantity: 1; Factory Stock: 0; @ qty: 1500; Value: 56; Tolerance: ±1%; Supplier Device Package: 0805; Size / Dimension: 0.079" L x 0.049" W (2.00mm x 1.25mm); Series: ERJ; Quantity Available: 46252; Part Status: Active; Part Number: ERJ-6ENF56R0V; Packaging: Cut Tape (CT); Package / Case: 0805 (2012 Metric); Operating Temperature: -55°C ~ 155°C; Number of Terminations: 2; Library Name: Panasonic_ERJ_0805.IntLib; Image: //media.digikey.com/Renders/Panasonic%20Renders/ERJ-0805%20Pkg.jpg; Height - Seated (Max): 0.028" (0.70mm); Features: Automotive AEC-Q200; Failure Rate: -; Digi-Key Part Number: P56.0CCT-ND; Datasheets: http://industrial.panasonic.com/www-cgi/jvcr13pz.cgi?E+PZ+3+AOA0002+ERJ6ENF56R0V+7+WW; Composition: Thick Film; Component Kind: Standard
R26 = ERJ-6ENF16R0V: RES SMD 16 OHM 1% 1/8W 0805; Manufacturer: Panasonic Electronic Components; Unit Price (USD): 0.00729; Temperature Coefficient: ±100ppm/°C; Power (Watts): 0.125W, 1/8W; Minimum Quantity: 1; Factory Stock: 0; @ qty: 1500; Value: 16; Tolerance: ±1%; Supplier Device Package: 0805; Size / Dimension: 0.079" L x 0.049" W (2.00mm x 1.25mm); Series: ERJ; Quantity Available: 3751; Part Status: Active; Part Number: ERJ-6ENF16R0V; Packaging: Cut Tape (CT); Package / Case: 0805 (2012 Metric); Operating Temperature: -55°C ~ 155°C; Number of Terminations: 2; Library Name: Panasonic_ERJ_0805.IntLib; Image: //media.digikey.com/Renders/Panasonic%20Renders/ERJ-0805%20Pkg.jpg; Height - Seated (Max): 0.028" (0.70mm); Features: Automotive AEC-Q200; Failure Rate: -; Digi-Key Part Number: P16.0CCT-ND; Datasheets: http://industrial.panasonic.com/www-cgi/jvcr13pz.cgi?E+PZ+3+AOA0002+ERJ6ENF16R0V+7+WW; Composition: Thick Film; Component Kind: Standard
S1 = SW-PB: Switch; Published: 24-Mar-1999; PackageReference: SPST-2; PackageDescription: Switch; 2 Leads; Library Name: Miscellaneous Devices.IntLib; LatestRevisionNote: Re-released for DXP Platform.; LatestRevisionDate: 17-Jul-2002; Component Kind: Standard; Publisher: Altium Limited
T1 = TR4432322_IV3: Ferrite switching transformer for IV-3 and IV-3A luminescent 7-segment indicator tubes. Primary: 4+4 turns; secondary 1: 32+32 turns; secondary 2: 2 turns.; Library Name: Transformer_4.4-32.32-2.2.SchLib; Component Kind: Standard
U1 = L7805CDT-TR: Positive Voltage Regulator, 3-Pin DPAK, Tape and Reel; PartNumber: L7805CDT-TR; PackageVersion: 2011; PackageReference: TO-252; PackageDescription: 3-Pin Transistor Outline Package 2.28 mm Pitch, 9.7 mm Lead Span (DPAK); Library Name: STMicroelectronics Power Management Linear Regulator.IntLib; DatasheetVersion: Nov-2011; ComponentLink2URL: http://www.st.com/internet/com/TECHNICAL_RESOURCES/TECHNICAL_LITERATURE/DATASHEET/CD00000444.pdf; ComponentLink2Description: Datasheet; ComponentLink1URL: http://www.st.com; ComponentLink1Description: Manufacturer URL; Component Kind: Standard
U2 = SN74HC595D: 8-Bit Shift Register with 3-State Output Registers; Signal Integrity: SN74HC595D; Published: 8-Jun-2000; PCB3D: D016; PackageVersion: Jan-1998; PackageReference: D016; PackageDescription: 16-Pin Small Outline Integrated Circuit 1.27 mm Pitch; Library Name: TI Logic Register.IntLib; LatestRevisionNote: IPC-7351 Footprint Added.; LatestRevisionDate: 13-Apr-2006; DatasheetVersion: May-1997; ComponentLink2URL: http://www-s.ti.com/sc/ds/sn74hc595.pdf; ComponentLink2Description: Datasheet; ComponentLink1URL: http://www.ti.com/; ComponentLink1Description: Manufacturer Link; Component Kind: Standard; Code_IPC: SOIC127P600-16; Publisher: Altium Limited
U4 = ATmega32A-PU: 8-bit AVR Microcontroller, 2.7-5.5V, 16MHz, 32KB Flash, 1KB EEPROM, 2KB SRAM, 40-pin PDIP, Industrial Grade (-40°C to 85°C); PackageVersion: revB, Sep-2001; PackageReference: 40P6; PackageDescription: 40-lead (0.600"/15.24mm Wide) Plastic Dual Inline Package (PDIP); Library Name: Atmel Microcontroller megaAVR.IntLib; DatasheetVersion: revC, Feb-2011; ComponentLink3URL: http://www.atmel.com/dyn/resources/prod_documents/8155S.pdf; ComponentLink3Description: ATmega32A Summary; ComponentLink2URL: http://www.atmel.com/dyn/resources/prod_documents/doc8155.pdf; ComponentLink2Description: ATmega32A Datasheet; ComponentLink1URL: http://www.atmel.com/; ComponentLink1Description: Manufacturer URL; Component Kind: Standard; Code_JEDEC: MS-011-AC
V1-V6 = IV-3A: IV-3A Luminescent 7-segment indicator tube.; Library Name: IV-3A tube.SchLib; Component Kind: Standard
Y1 = ECS-60-32-1: Crystal Oscillator; Simulation: XTAL; Published: 17-Jul-2002; PackageReference: HC-49U; Library Name: ECS Crystal Oscillator.IntLib; DatasheetDocument: 18-Apr-2000; ComponentLink2URL: http://www.ecsxtal.com/pdf2/HC49U.PDF; ComponentLink2Description: Datasheet; ComponentLink1URL: http://www.ecsxtal.com/; ComponentLink1Description: Manufacturer Link; Component Kind: Standard; Publisher: Altium Limited
U3 = TD62783AP: Library Name: TD62783AP.SchLib; Component Kind: Standard
